# Altium schematic sheet MicroSD.SchDoc(MicroSD)
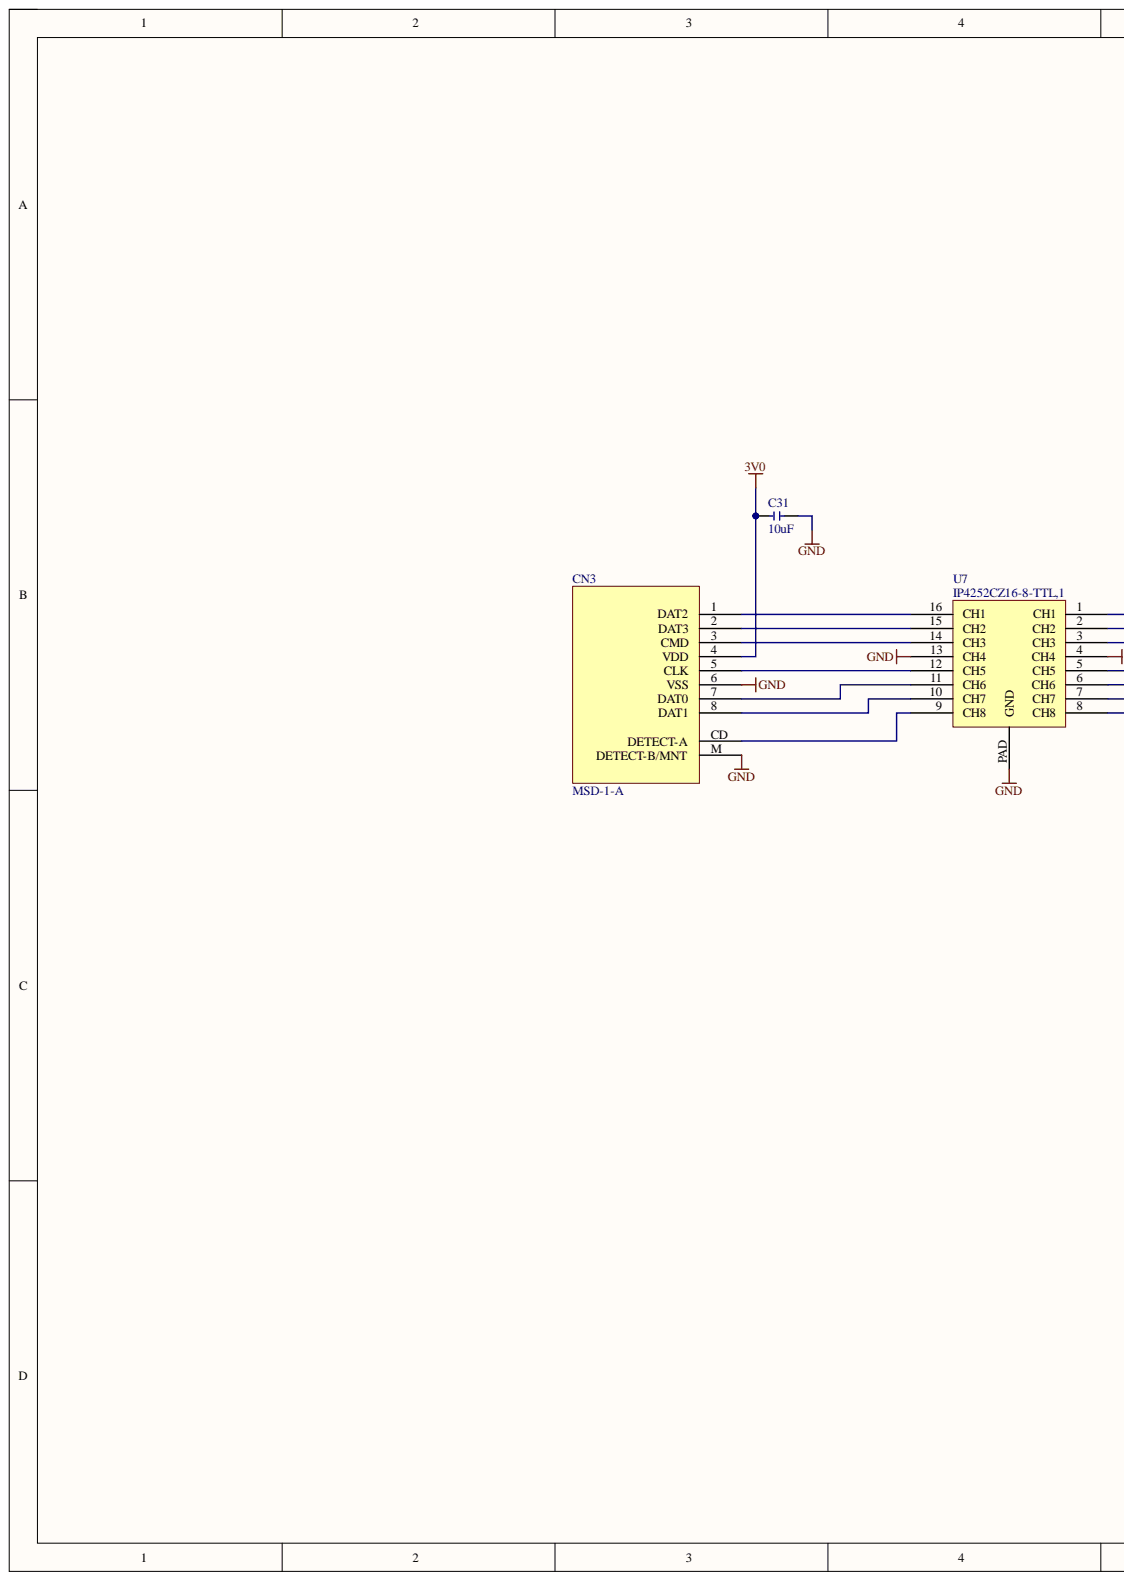
[diagram p0: MicroSD.SchDoc, left]
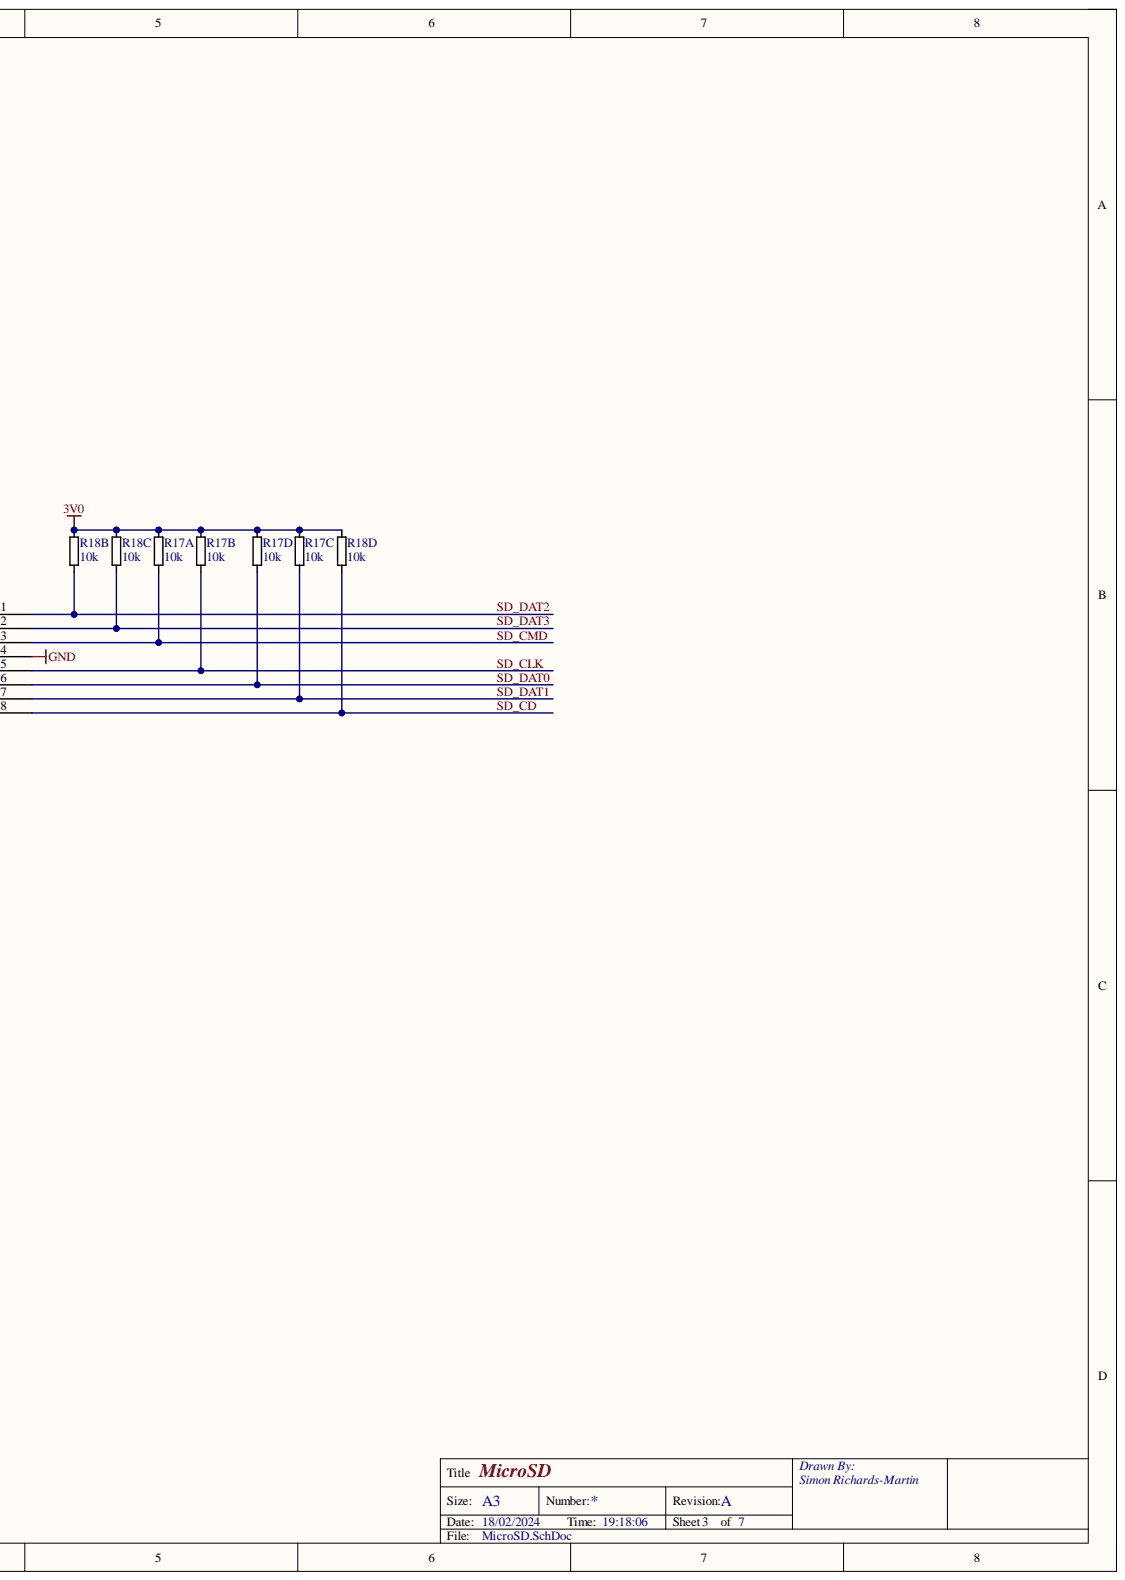
[diagram p1: MicroSD.SchDoc, right]

## Parts
C31  10uF  [CHIP-0402]
CN3  MSD-1-A  [MSD-1-A]
R17  10k  [CAY10]  (parts A,B,C,D)
R18  10k  [CAY10]  (parts B,C,D)
U7  IP4252CZ16-8-TTL,1  [SON40P140X55_HS-17N]

## Nets
3V0: C31.1 CN3.4(VDD) R17.5 R17.6 R17.7 R17.8 R18.5 R18.6 R18.7
GND: C31.2 CN3.6(VSS) CN3.M(DETECT-B/MNT) U7.4(CH4) U7.13(CH4) U7.PAD(GND)
SD_CD: R18.4 U7.8(CH8)
SD_CLK: R17.2 U7.5(CH5)
SD_CMD: R17.1 U7.3(CH3)
SD_DAT0: R17.4 U7.6(CH6)
SD_DAT1: R17.3 U7.7(CH7)
SD_DAT2: R18.2 U7.1(CH1)
SD_DAT3: R18.3 U7.2(CH2)
NetCN3_1: CN3.1(DAT2) U7.16(CH1)
NetCN3_2: CN3.2(DAT3) U7.15(CH2)
NetCN3_3: CN3.3(CMD) U7.14(CH3)
NetCN3_5: CN3.5(CLK) U7.12(CH5)
NetCN3_7: CN3.7(DAT0) U7.11(CH6)
NetCN3_8: CN3.8(DAT1) U7.10(CH7)
NetCN3_CD: CN3.CD(DETECT-A) U7.9(CH8)

## Library data of the parts
C31 = Capacitor 10uF 10V 0402 X5R: Manufacturer: Murata; MPN: GRM155R61A106ME11D; Component Kind: Standard; Description: Capacitor 10uF 10V 0402 X5R; Library Name: Paramotor Sensor Main.SchLib
CN3 = MSD-1-A: MicroSD SMT Push-Push; Manufacturer: CUI Devices; Component Kind: Standard; MPN: MSD-1-A; Library Name: Paramotor Sensor Main.SchLib
R17 R18 = Resistor 4 Array 10k 5% 0804: Manufacturer: Bourns; MPN: CAY10-103J4LF; Component Kind: Standard; Description: Resistor 4 Array 10k 5% 0804; Library Name: Paramotor Sensor Main.SchLib
U7 = IP4252CZ16-8-TTL,1: EMI Filter + ESD 12pF 40Ohms 8CH; Manufacturer: Nexperia; Component Kind: Standard; MPN: IP4252CZ16-8-TTL,1; Library Name: Paramotor Sensor Main.SchLib
